# Altium schematic sheet BTS7960.SchDoc
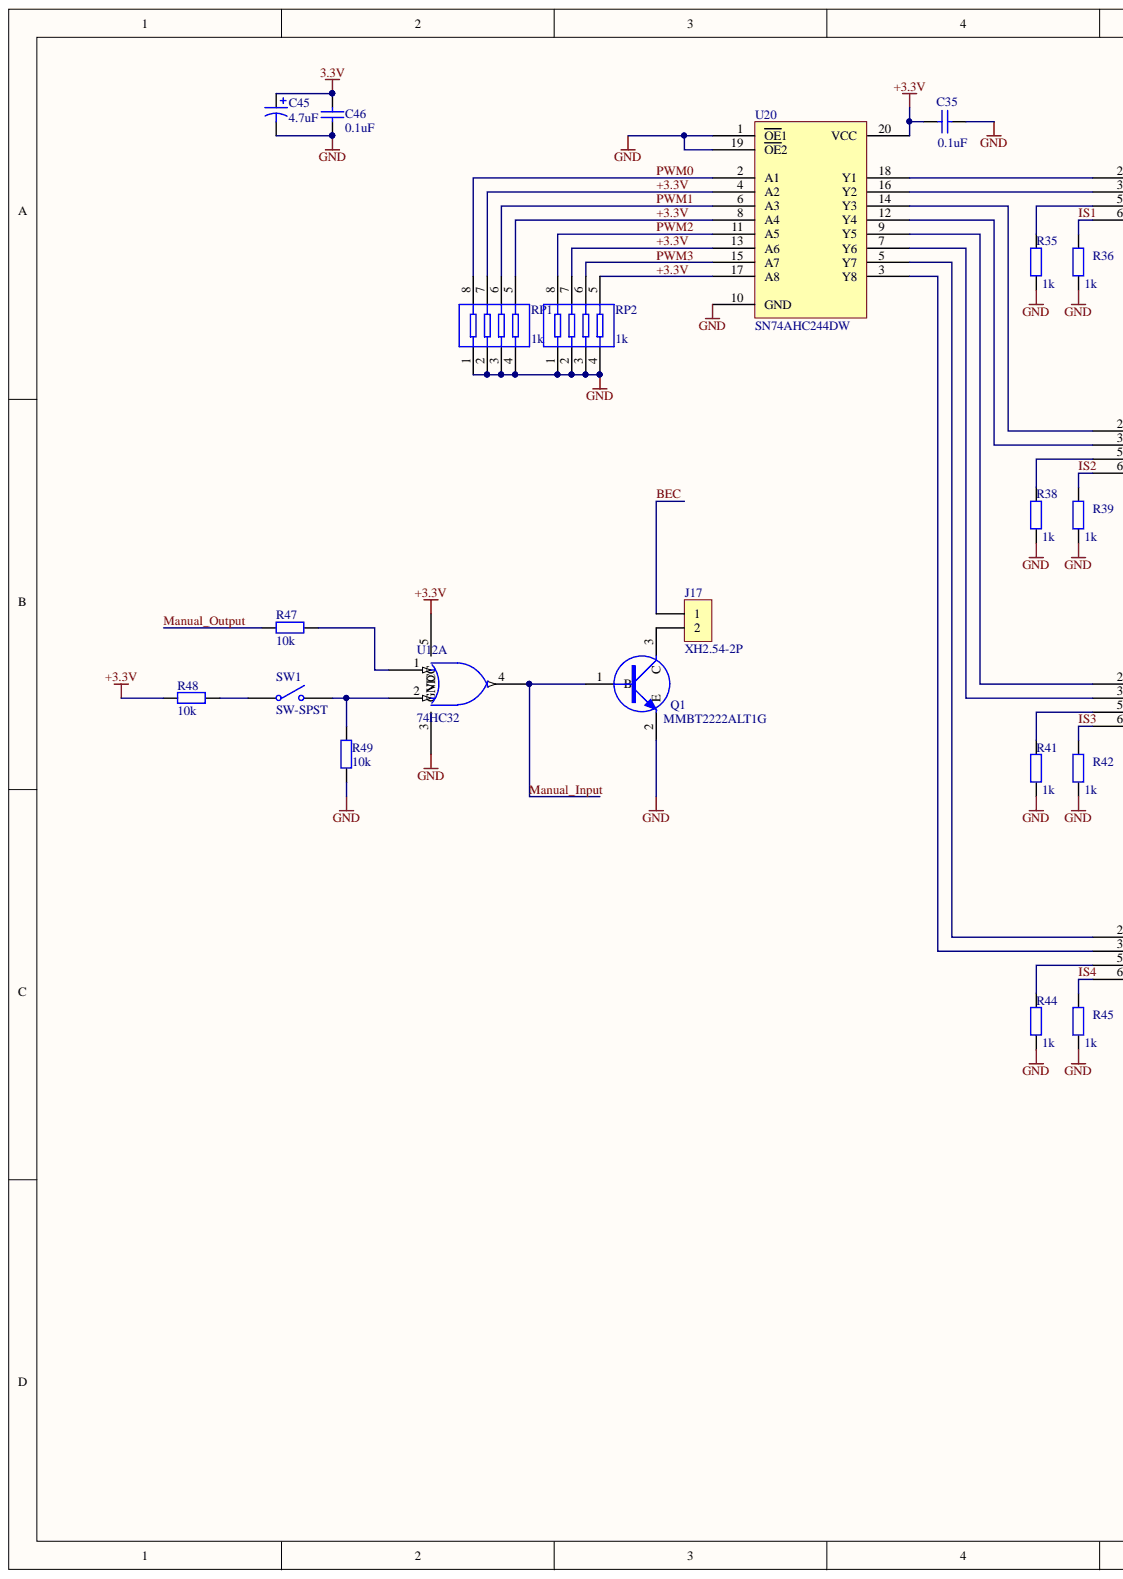
[diagram p0: BTS7960.SchDoc, left]
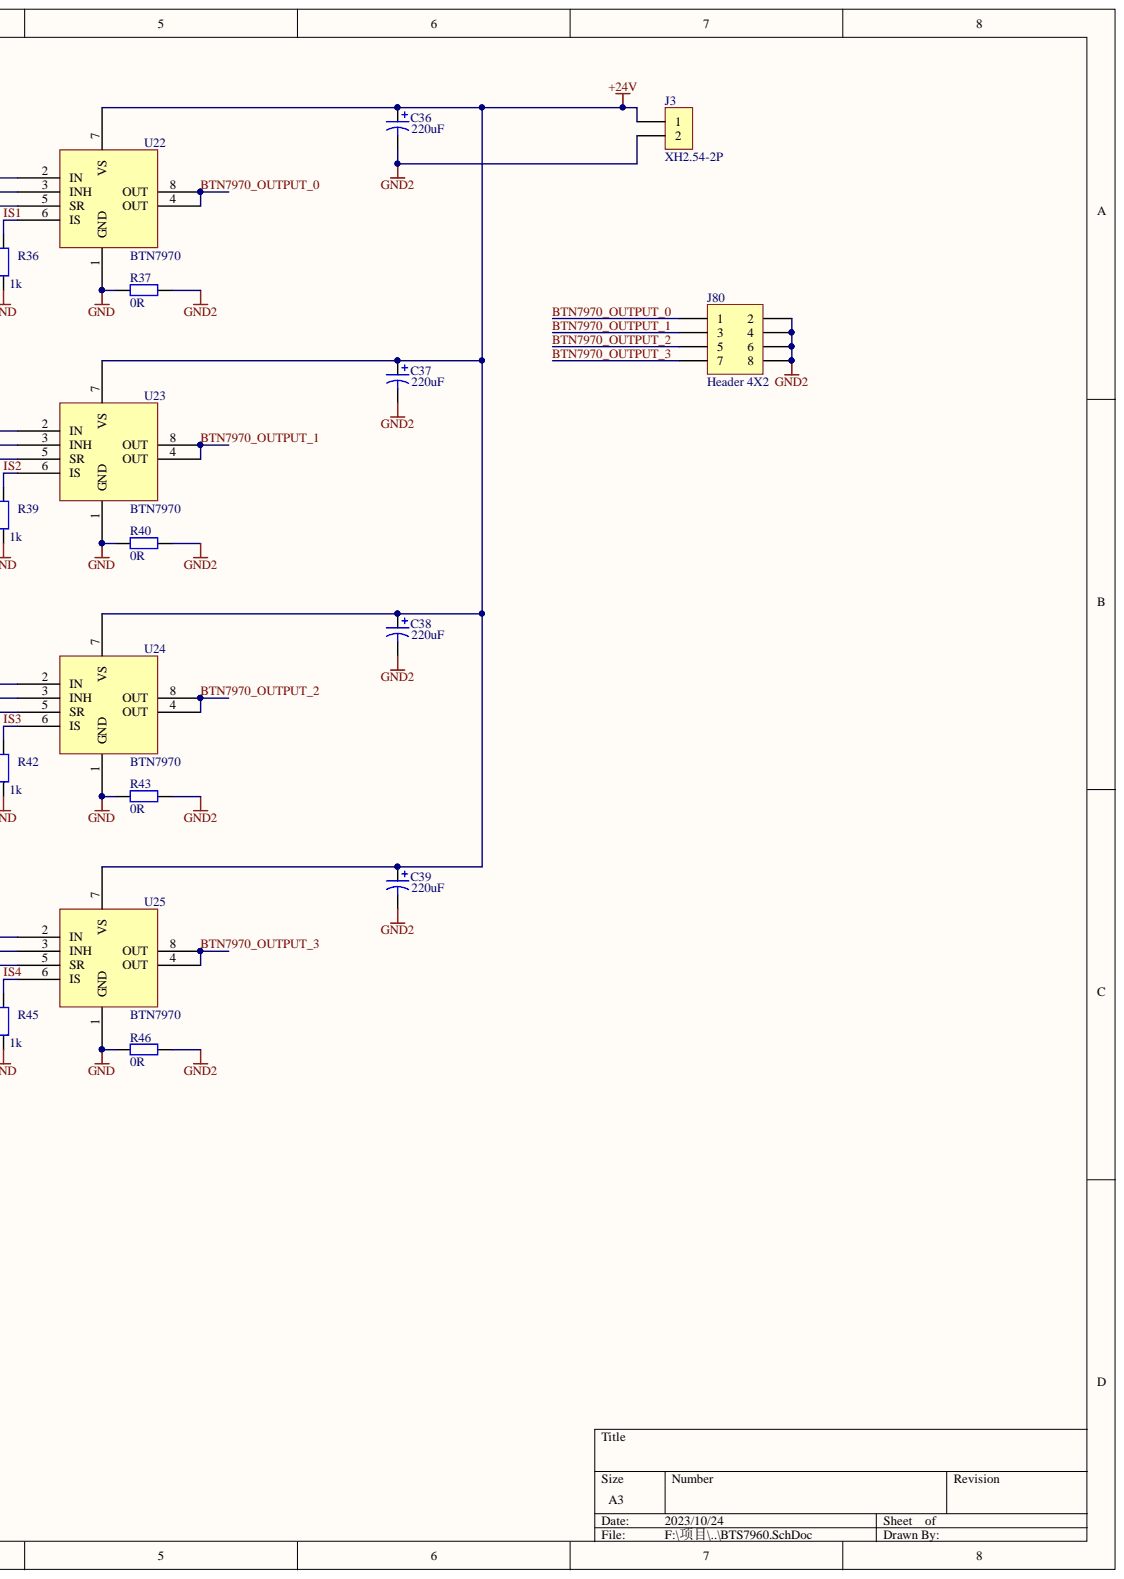
[diagram p1: BTS7960.SchDoc, right]

## Parts
C35  value 0.1uF  [CC0805]  (hidden comment: 0805-50V-0.1uF)
C36  value 220uF  [SMD-EC-F8]  (hidden comment: CD-50V-220uF-F8(SMD))
C37  value 220uF  [SMD-EC-F8]  (hidden comment: CD-50V-220uF-F8(SMD))
C38  value 220uF  [SMD-EC-F8]  (hidden comment: CD-50V-220uF-F8(SMD))
C39  value 220uF  [SMD-EC-F8]  (hidden comment: CD-50V-220uF-F8(SMD))
C45  value 4.7uF  [SMD-EC-F5]  (hidden comment: CD-50V-4.7uF-F5(SMD))
C46  value 0.1uF  [CC0805]  (hidden comment: 0805-50V-0.1uF)
J3  XH2.54-2P  [XH2.54-2P]
J17  XH2.54-2P  [XH2.54-2P]
J80  Header 4X2  [HDR2X4]
Q1  value MMBT2222ALT1G  [SOT23]  (hidden comment: MMBT2222ALT1G(SOT-23))
R35  value 1k  [0805]  (hidden comment: 0805-1kΩ±1％)
R36  value 1k  [0805]  (hidden comment: 0805-1kΩ±1％)
R37  value 0R  [0805]  (hidden comment: 0805-0Ω±1％)
R38  value 1k  [0805]  (hidden comment: 0805-1kΩ±1％)
R39  value 1k  [0805]  (hidden comment: 0805-1kΩ±1％)
R40  value 0R  [0805]  (hidden comment: 0805-0Ω±1％)
R41  value 1k  [0805]  (hidden comment: 0805-1kΩ±1％)
R42  value 1k  [0805]  (hidden comment: 0805-1kΩ±1％)
R43  value 0R  [0805]  (hidden comment: 0805-0Ω±1％)
R44  value 1k  [0805]  (hidden comment: 0805-1kΩ±1％)
R45  value 1k  [0805]  (hidden comment: 0805-1kΩ±1％)
R46  value 0R  [0805]  (hidden comment: 0805-0Ω±1％)
R47  value 10k  [0805]  (hidden comment: 0805-10kΩ±1％)
R48  value 10k  [0805]  (hidden comment: 0805-10kΩ±1％)
R49  value 10k  [0805]  (hidden comment: 0805-10kΩ±1％)
RP1  value 1k  [SSOP16_N]  (hidden comment: Res Pack4)
RP2  value 1k  [SSOP16_N]  (hidden comment: Res Pack4)
SW1  SW-SPST  [SPST-2]
U12  74HC32  [SO-14]  (part A)
U20  SN74AHC244DW  [SOP-20]
U22  BTN7970  [BTN7970]
U23  BTN7970  [BTN7970]
U24  BTN7970  [BTN7970]
U25  BTN7970  [BTN7970]

## Nets
3.3V: C45.1 C46.2
+24V: C36.1 C37.1 C38.1 C39.1 J3.1 U22.7(VS) U23.7(VS) U24.7(VS) U25.7(VS)
GND: C35.2 C45.2 C46.1 Q1.2 R35.1 R36.1 R37.2 R38.1 R39.1 R40.2 R41.1 R42.1 R43.2 R44.1 R45.1 R46.2 R49.2 RP1.1 RP1.2 RP1.3 RP1.4 RP2.1 RP2.2 RP2.3 RP2.4 U12.3(GND) U20.1(OE1) U20.10(GND) U20.19(OE2) U22.1(GND) U23.1(GND) U24.1(GND) U25.1(GND)
GND2: C36.2 C37.2 C38.2 C39.2 J3.2 J80.2 J80.4 J80.6 J80.8 R37.1 R40.1 R43.1 R46.1
+3.3V: C35.1 R48.2 RP1.5 RP1.7 RP2.5 RP2.7 U12.5(VCC) U20.4(A2) U20.8(A4) U20.13(A6) U20.17(A8) U20.20(VCC)
BEC: J17.1
BTN7970_OUTPUT_0: J80.1 U22.4(OUT) U22.8(OUT)
BTN7970_OUTPUT_1: J80.3 U23.4(OUT) U23.8(OUT)
BTN7970_OUTPUT_2: J80.5 U24.4(OUT) U24.8(OUT)
BTN7970_OUTPUT_3: J80.7 U25.4(OUT) U25.8(OUT)
IS1: R36.2 U22.6(IS)
IS2: R39.2 U23.6(IS)
IS3: R42.2 U24.6(IS)
IS4: R45.2 U25.6(IS)
MANUAL_INPUT: Q1.1 U12.4
MANUAL_OUTPUT: R47.2
PWM0: RP1.8 U20.2(A1)
PWM1: RP1.6 U20.6(A3)
PWM2: RP2.8 U20.11(A5)
PWM3: RP2.6 U20.15(A7)
NetJ17_2: J17.2 Q1.3
NetR35_2: R35.2 U22.5(SR)
NetR38_2: R38.2 U23.5(SR)
NetR41_2: R41.2 U24.5(SR)
NetR44_2: R44.2 U25.5(SR)
NetR47_1: R47.1 U12.1
NetR48_1: R48.1 SW1.1
NetR49_1: R49.1 SW1.2 U12.2
NetU20_3: U20.3(Y8) U25.3(INH)
NetU20_5: U20.5(Y7) U25.2(IN)
NetU20_7: U20.7(Y6) U24.3(INH)
NetU20_9: U20.9(Y5) U24.2(IN)
NetU20_12: U20.12(Y4) U23.3(INH)
NetU20_14: U20.14(Y3) U23.2(IN)
NetU20_16: U20.16(Y2) U22.3(INH)
NetU20_18: U20.18(Y1) U22.2(IN)

## Library data of the parts
C35 C46 = Cap: 贴片电容; Component Kind: Standard; Library Name: Miscellaneous Devices.IntLib
  C35: Signal Integrity: Cap; Simulation: CAP
C36-C39 = Cap Pol3: 贴片铝电解电容; Component Kind: Standard; Library Name: Miscellaneous Devices.IntLib; Signal Integrity: Cap Pol; Simulation: CAP
C45 = Cap Pol1: 贴片铝电解电容; Component Kind: Standard; Library Name: Miscellaneous Devices.IntLib
J3 J17 = CON2: 插座; Component Kind: Standard; Value: XH2.54-2P
J80 = Header 4X2: Header, 4-Pin, Dual row; Component Kind: Standard; LatestRevisionDate: 17-Jul-2002; LatestRevisionNote: Re-released for DXP Platform.; Library Name: Miscellaneous Connectors.IntLib; Signal Integrity: Connector; Publisher: Altium Limited
Q1 = S9013: 贴片三极管; Component Kind: Standard
R35-R49 = Res2: 贴片电阻; Component Kind: Standard; Library Name: Miscellaneous Devices.IntLib; Signal Integrity: Res; Simulation: RESISTOR
RP1 RP2 = Res Pack4: Isolated Resistor Network; Code_IPC: SOP65P780-16; Code_JEDEC: MO-150-AC; Component Kind: Standard; LatestRevisionDate: 29-May-2009; LatestRevisionNote: IPC-7351 Footprint Added.; Library Name: SHIELD.SCHLIB; PackageDescription: 16-Pin Plastic Small Outline Package 0.65 mm Pitch; PackageReference: SSOP16; PackageVersion: Oct-2003; Published: 24-Mar-1999; Simulation: respack_8; Publisher: Altium Limited
SW1 = SW-SPST: Single-Pole, Single-Throw Switch; Component Kind: Standard; LatestRevisionDate: 17-Jul-2002; LatestRevisionNote: Re-released for DXP Platform.; Library Name: Miscellaneous Devices.IntLib; PackageDescription: Switch; 2 Leads; PackageReference: SPST-2; Published: 24-Mar-1999; Publisher: Altium Limited
U12 = 74LS32: Component Kind: Standard
U20 = SN74AHC244DW: 集成电路; Component Kind: Standard; Library Name: Schlib2.SchLib; Value: SN74AHC244DW
U22-U25 = BTN7970: 集成电路; Component Kind: Standard; Library Name: Schlib2.SchLib; Value: BTN7970
